# Altium schematic sheet Microbit LED Matrix.SchDoc
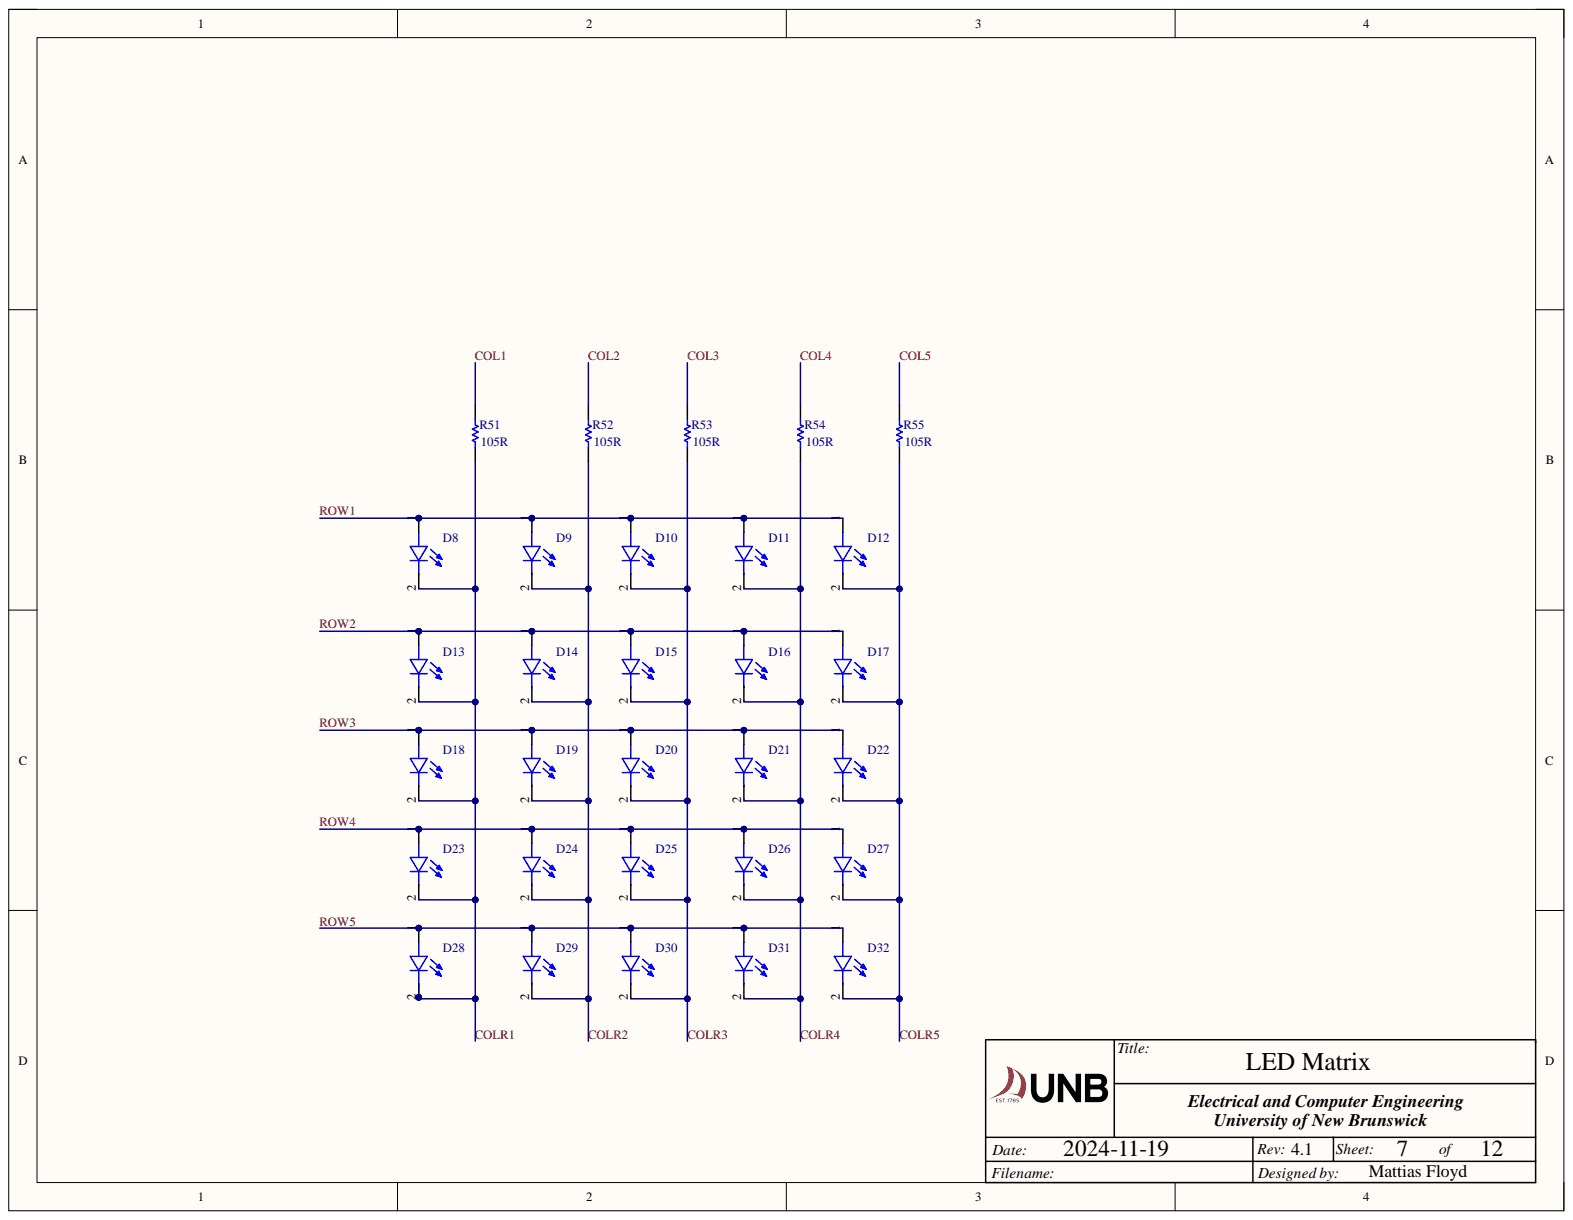
[diagram p0: Microbit LED Matrix.SchDoc]

## Parts
D8  LED SML-LXFM0603SIC-TR  [0603 LED]  (value not drawn)
D9  LED SML-LXFM0603SIC-TR  [0603 LED]  (value not drawn)
D10  LED SML-LXFM0603SIC-TR  [0603 LED]  (value not drawn)
D11  LED SML-LXFM0603SIC-TR  [0603 LED]  (value not drawn)
D12  LED SML-LXFM0603SIC-TR  [0603 LED]  (value not drawn)
D13  LED SML-LXFM0603SIC-TR  [0603 LED]  (value not drawn)
D14  LED SML-LXFM0603SIC-TR  [0603 LED]  (value not drawn)
D15  LED SML-LXFM0603SIC-TR  [0603 LED]  (value not drawn)
D16  LED SML-LXFM0603SIC-TR  [0603 LED]  (value not drawn)
D17  LED SML-LXFM0603SIC-TR  [0603 LED]  (value not drawn)
D18  LED SML-LXFM0603SIC-TR  [0603 LED]  (value not drawn)
D19  LED SML-LXFM0603SIC-TR  [0603 LED]  (value not drawn)
D20  LED SML-LXFM0603SIC-TR  [0603 LED]  (value not drawn)
D21  LED SML-LXFM0603SIC-TR  [0603 LED]  (value not drawn)
D22  LED SML-LXFM0603SIC-TR  [0603 LED]  (value not drawn)
D23  LED SML-LXFM0603SIC-TR  [0603 LED]  (value not drawn)
D24  LED SML-LXFM0603SIC-TR  [0603 LED]  (value not drawn)
D25  LED SML-LXFM0603SIC-TR  [0603 LED]  (value not drawn)
D26  LED SML-LXFM0603SIC-TR  [0603 LED]  (value not drawn)
D27  LED SML-LXFM0603SIC-TR  [0603 LED]  (value not drawn)
D28  LED SML-LXFM0603SIC-TR  [0603 LED]  (value not drawn)
D29  LED SML-LXFM0603SIC-TR  [0603 LED]  (value not drawn)
D30  LED SML-LXFM0603SIC-TR  [0603 LED]  (value not drawn)
D31  LED SML-LXFM0603SIC-TR  [0603 LED]  (value not drawn)
D32  LED SML-LXFM0603SIC-TR  [0603 LED]  (value not drawn)
R51  Resistance: 105R  [0402 RES]  (hidden comment: RES ERJ-2RKF1050X)
R52  Resistance: 105R  [0402 RES]  (hidden comment: RES ERJ-2RKF1050X)
R53  Resistance: 105R  [0402 RES]  (hidden comment: RES ERJ-2RKF1050X)
R54  Resistance: 105R  [0402 RES]  (hidden comment: RES ERJ-2RKF1050X)
R55  Resistance: 105R  [0402 RES]  (hidden comment: RES ERJ-2RKF1050X)

## Nets
COL1: R51.2
COL2: R52.2
COL3: R53.2
COL4: R54.2
COL5: R55.2
COLR1: D8.2 D13.2 D18.2 D23.2 D28.2 R51.1
COLR2: D9.2 D14.2 D19.2 D24.2 D29.2 R52.1
COLR3: D10.2 D15.2 D20.2 D25.2 D30.2 R53.1
COLR4: D11.2 D16.2 D21.2 D26.2 D31.2 R54.1
COLR5: D12.2 D17.2 D22.2 D27.2 D32.2 R55.1
ROW1: D8.1 D9.1 D10.1 D11.1 D12.1
ROW2: D13.1 D14.1 D15.1 D16.1 D17.1
ROW3: D18.1 D19.1 D20.1 D21.1 D22.1
ROW4: D23.1 D24.1 D25.1 D26.1 D27.1
ROW5: D28.1 D29.1 D30.1 D31.1 D32.1

## Library data of the parts
D8-D32 = LED SML-LXFM0603SIC-TR: Single Color LED, Super High Intensity Red, Clear, 1.2mm; Manufacturer: Lumex; Forward Current-Max: 30mA; Forward Voltage-Max: 2.6V; Luminous Intensity-Nom: 150mcd; Number of Functions: 1; Overall Height: 1.1mm; Shape: Rectangular; Viewing Angle: 140°; Category: LEDs; Color: Red; Color@wavelength: Red; Component Kind: Standard; ComponentLink1Description: https://datasheet.ciiva.com:443/pdfs/VipMasterIC/IC/LUMX/LUMX-S-A0001165602/LUMX-S-A0001165602-1.pdf?src-supplier=IHS+Markit; ComponentLink1URL: https://datasheet.ciiva.com:443/pdfs/VipMasterIC/IC/LUMX/LUMX-S-A0001165602/LUMX-S-A0001165602-1.pdf?src-supplier=IHS+Markit; ComponentLink2Description: https://datasheet.ciiva.com/18614/sml-lxfm0603sic-tr-18614465.pdf?src-supplier=Digikey; ComponentLink2URL: https://datasheet.ciiva.com/18614/sml-lxfm0603sic-tr-18614465.pdf?src-supplier=Digikey; ComponentLink3Description: https://datasheet.ciiva.com/8072/685489-8072896.pdf?src-supplier=Element14; ComponentLink3URL: https://datasheet.ciiva.com/8072/685489-8072896.pdf?src-supplier=Element14; ComponentLink4Description: https://datasheet.ciiva.com/4690/sml-lxfm0603sic-tr-111845-4690226.pdf?src-supplier=Mouser; ComponentLink4URL: https://datasheet.ciiva.com/4690/sml-lxfm0603sic-tr-111845-4690226.pdf?src-supplier=Mouser; ComponentLink5Description: https://datasheet.ciiva.com/18614/sml-lxfm0603sic-tr-18614437.pdf?src-supplier=Digikey; ComponentLink5URL: https://datasheet.ciiva.com/18614/sml-lxfm0603sic-tr-18614437.pdf?src-supplier=Digikey; ComponentLink6Description: https://datasheet.ciiva.com/18614/sml-lxfm0603sic-tr-18614438.pdf?src-supplier=Digikey; ComponentLink6URL: https://datasheet.ciiva.com/18614/sml-lxfm0603sic-tr-18614438.pdf?src-supplier=Digikey; ComponentLink7Description: https://datasheet.ciiva.com/16427/sml-lxfm0603sic-tr-16427418.pdf?src-supplier=Future+Electronics; ComponentLink7URL: https://datasheet.ciiva.com/16427/sml-lxfm0603sic-tr-16427418.pdf?src-supplier=Future+Electronics; Lens Style: Clear; Manufacturer Part Number: SML-LXFM0603SIC-TR; Max Operating Temperature: 85°C; Min Operating Temperature: -40°C; Mount: Surface Mount; Number of Pins: 2; Optoelectronic Device Type: SINGLE COLOR LED; Packaging: Tape and Reel; Pricing 1: 1=0.35, 10=0.231, 100=0.1526, 1000=0.125 (USD); Reach SVHC Compliant: Yes; RoHS: Yes; RoHS Compliant: Yes; Size: 1.2mm; Stock 1: 22097; Supplier 1: Digikey; Supplier Part Number 1: 67-2194-6-ND; Terminal Pitch: 1.65mm; Library Name: bBoard for UNB Rev 4.SCHLIB
R51-R55 = RES ERJ-2RKF1050X: Fixed Resistor, Metal Glaze/thick Film, 0.1W, 105ohm, 50V, 1% +/-Tol, 100ppm/Cel, Surface Mount, 0402; Manufacturer: Panasonic; Package Height: 0.35mm; Package Length: 1mm; Package Width: 0.5mm; Rated Power Dissipation (P): 100mW; Rated Temperature: 70°C; Reference Standard: AEC-Q200; Temperature Coefficient: 100ppm/°C; Category: Chip SMD Resistors; Component Kind: Standard; ComponentLink1Description: https://datasheet.ciiva.com:443/pdfs/VipMasterIC/IC/PANA/PANA-S-A0009889626/PANA-S-A0009889626-1.pdf?src-supplier=IHS+Markit; ComponentLink1URL: https://datasheet.ciiva.com:443/pdfs/VipMasterIC/IC/PANA/PANA-S-A0009889626/PANA-S-A0009889626-1.pdf?src-supplier=IHS+Markit; ComponentLink2Description: https://datasheet.ciiva.com/9119/aoa0000ce2-9119247.pdf?src-supplier=Avnet; ComponentLink2URL: https://datasheet.ciiva.com/9119/aoa0000ce2-9119247.pdf?src-supplier=Avnet; ComponentLink3Description: https://datasheet.ciiva.com/43467/1678782-43467224.pdf?src-supplier=Farnell; ComponentLink3URL: https://datasheet.ciiva.com/43467/1678782-43467224.pdf?src-supplier=Farnell; ComponentLink4Description: https://datasheet.ciiva.com/16764/70260748-16764263.pdf?src-supplier=Allied+Electronics; ComponentLink4URL: https://datasheet.ciiva.com/16764/70260748-16764263.pdf?src-supplier=Allied+Electronics; ComponentLink5Description: https://datasheet.ciiva.com/16768/70260748-16768203.pdf?src-supplier=Allied+Electronics; ComponentLink5URL: https://datasheet.ciiva.com/16768/70260748-16768203.pdf?src-supplier=Allied+Electronics; ComponentLink6Description: https://datasheet.ciiva.com/7903/1678782-7903401.pdf?src-supplier=Element14; ComponentLink6URL: https://datasheet.ciiva.com/7903/1678782-7903401.pdf?src-supplier=Element14; ComponentLink7Description: https://datasheet.ciiva.com/24069/aoa0000ce2-24069577.pdf?src-supplier=Mouser; ComponentLink7URL: https://datasheet.ciiva.com/24069/aoa0000ce2-24069577.pdf?src-supplier=Mouser; Construction: Rectangular; JESD-609 Code: e3; Manufacturer Part Number: ERJ-2RKF1050X; Max Operating Temperature: 155°C; Min Operating Temperature: -55°C; Mount: Surface Mount; Number of Pins: 2; Package Shape: Rectangular; Package Style: SMTMeter; Packaging: Tape and Reel; Pricing 1: 1=0.1, 10=0.024, 50=0.0152, 100=0.0127, 500=0.00866, 1000=0.00746, 5000=0.00542 (USD); Reach SVHC Compliant: Yes; Resistor Type: FIXED RESISTOR; RoHS: Yes; RoHS Compliant: Yes; Size Code: 0402; Stock 1: 5968; Supplier 1: Digikey; Supplier Part Number 1: P105LCT-ND; Technology: METAL GLAZE/THICK FILM; Terminal Finish: Nickel; Termination: Wraparound; Tolerance: 1%; Working Voltage: 50V; Library Name: bBoard for UNB Rev 4.SCHLIB
